# Altium schematic sheet BARBAROV Power Distrubution Board.SchDoc("BARBAROV Power Distrubution Board")
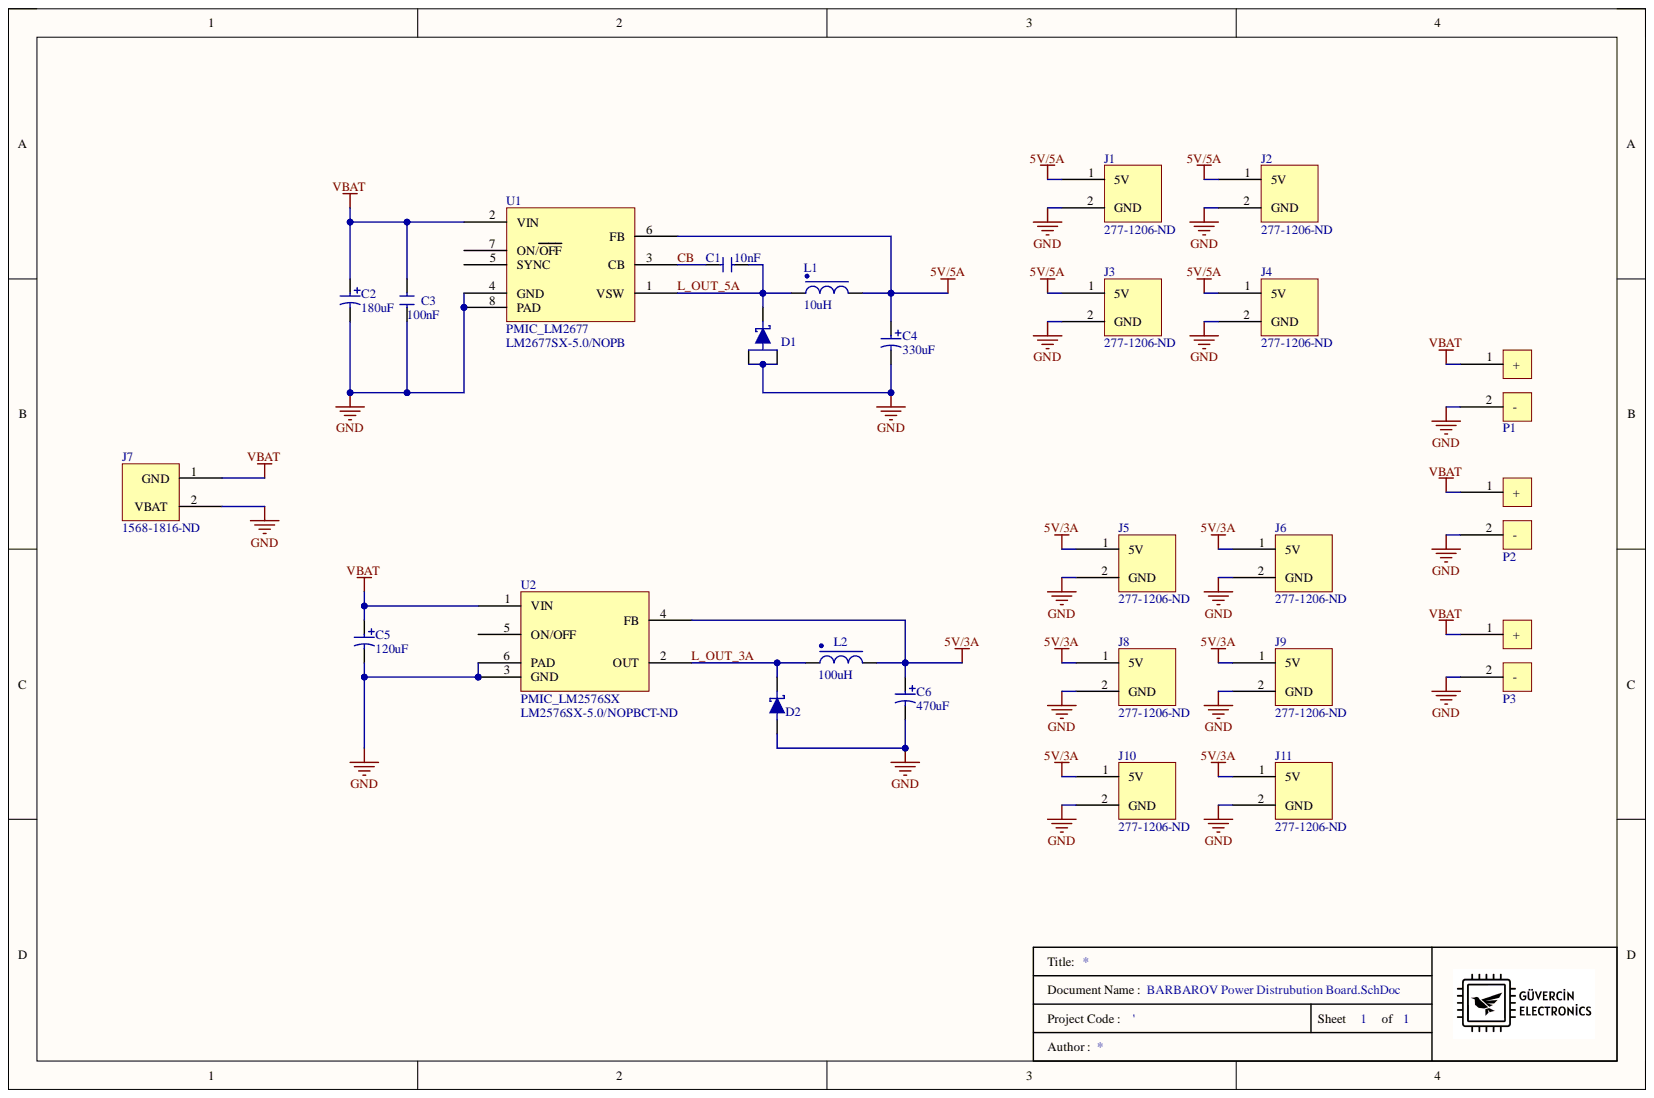
[diagram p0: BARBAROV Power Distrubution Board.SchDoc]

## Parts
C1  Capacitance: 10nF  [0603_CAP]  (hidden comment: CAP_10nF_%20_0603_50V_X7R_CER)
C2  Capacitance: 180uF  [RADIAL-2_8.30MMX8.30MM_PANASONIC]  (hidden comment: CAP_180uF_20%_25V_SMD_ALUM POLY_8.30mmx8.30mm)
C3  Capacitance: 100nF  [0805_CAP]  (hidden comment: CAP_100nF_50V_0805_C0G_CER)
C4  Capacitance: 330uF  [RADIAL-2_8.30MMX8.30MM_PANASONIC]  (hidden comment: CAP_330uF_20%_16V_SMD_ALUM POLY_8.30mm x 8.30mm)
C5  Capacitance: 120uF  [CAP_6SVPC330M]  (hidden comment: CAP_120uF_20%_20V_ALUM POLY_SMD)
C6  470uF  [CAP_EEVTG1C471Q]
D1  DIODE_SCHOTTKY_200V_10A_DPAK  [3PIN_DPAK_DIODE]  (value not drawn)
D2  DIODE_SCHOTTKY_60V_5A_SMC  [DIOM6959X25M]  (value not drawn)
J1  Supplier Part Number 1: 277-1206-ND  [CONN TERM BLOCK HDR 2POS 90DEG 3.81MM]  (hidden comment: CONN_TERM BLOCK_HDR_2POS_VERT_3.81MM)
J2  Supplier Part Number 1: 277-1206-ND  [CONN TERM BLOCK HDR 2POS 90DEG 3.81MM]  (hidden comment: CONN_TERM BLOCK_HDR_2POS_VERT_3.81MM)
J3  Supplier Part Number 1: 277-1206-ND  [CONN TERM BLOCK HDR 2POS 90DEG 3.81MM]  (hidden comment: CONN_TERM BLOCK_HDR_2POS_VERT_3.81MM)
J4  Supplier Part Number 1: 277-1206-ND  [CONN TERM BLOCK HDR 2POS 90DEG 3.81MM]  (hidden comment: CONN_TERM BLOCK_HDR_2POS_VERT_3.81MM)
J5  Supplier Part Number 1: 277-1206-ND  [CONN TERM BLOCK HDR 2POS 90DEG 3.81MM]  (hidden comment: CONN_TERM BLOCK_HDR_2POS_VERT_3.81MM)
J6  Supplier Part Number 1: 277-1206-ND  [CONN TERM BLOCK HDR 2POS 90DEG 3.81MM]  (hidden comment: CONN_TERM BLOCK_HDR_2POS_VERT_3.81MM)
J7  Supplier Part Number 1: 1568-1816-ND  [XT60_CONN]  (hidden comment: CONN_XT60)
J8  Supplier Part Number 1: 277-1206-ND  [CONN TERM BLOCK HDR 2POS 90DEG 3.81MM]  (hidden comment: CONN_TERM BLOCK_HDR_2POS_VERT_3.81MM)
J9  Supplier Part Number 1: 277-1206-ND  [CONN TERM BLOCK HDR 2POS 90DEG 3.81MM]  (hidden comment: CONN_TERM BLOCK_HDR_2POS_VERT_3.81MM)
J10  Supplier Part Number 1: 277-1206-ND  [CONN TERM BLOCK HDR 2POS 90DEG 3.81MM]  (hidden comment: CONN_TERM BLOCK_HDR_2POS_VERT_3.81MM)
J11  Supplier Part Number 1: 277-1206-ND  [CONN TERM BLOCK HDR 2POS 90DEG 3.81MM]  (hidden comment: CONN_TERM BLOCK_HDR_2POS_VERT_3.81MM)
L1  Inductance: 10uH  [IND13.70MM13.70MMX7MM]  (hidden comment: IND_10uH_10A_16.8MOHM_SMD)
L2  Inductance: 100uH  [SMD_IND_22.48MMX22.00MM]  (hidden comment: IND_100uH_9.1A_39.4MOHM_SM)
P1  ESC_PAD  [ESC_SOLDER_PAD]  (value not drawn)  (no BOM)
P2  ESC_PAD  [ESC_SOLDER_PAD]  (value not drawn)  (no BOM)
P3  ESC_PAD  [ESC_SOLDER_PAD]  (value not drawn)  (no BOM)
U1  PMIC_LM2677  Manufacturer Part Number: LM2677SX-5.0/NOPB  [DPAK127P1435X464-8M]
U2  PMIC_LM2576SX  Supplier Part Number 1: LM2576SX-5.0/NOPBCT-ND  [TO-263-6/DDPAK]

## Nets
5V/3A: C6.1 J5.1 J6.1 J8.1 J9.1 J10.1 J11.1 L2.2 U2.4(FB)
5V/5A: C4.1 J1.1 J2.1 J3.1 J4.1 L1.2 U1.6(FB)
GND: C2.2 C3.2 C4.2 C5.2 C6.2 D1.1 D1.3 D2.2 J1.2 J2.2 J3.2 J4.2 J5.2 J6.2 J7.2 J8.2 J9.2 J10.2 J11.2 P1.2 P2.2 P3.2 U1.4(GND) U1.8(PAD) U2.3(GND) U2.6(PAD)
VBAT: C2.1 C3.1 C5.1 J7.1 P1.1 P2.1 P3.1 U1.2(VIN) U2.1(VIN)
CB: C1.1 U1.3(CB)
L_OUT_3A: D2.1 L2.1 U2.2(OUT)
L_OUT_5A: C1.2 D1.2 L1.1 U1.1(VSW)

Not connected: U1 5(SYNC) 7(ON/OFF); U2 5(ON/OFF)

## Library data of the parts
C1 = CAP_10nF_%20_0603_50V_X7R_CER: Cap Ceramic 0.01uF 50V X7R 20% SMD 0603 125°C Paper T/R; Manufacturer: KEMET; Manufacturer Part Number: C0603C103M5RAC7411; Case Code (Metric): 1608; Case Code (Imperial): 0603; Case/Package: 0603; Category: Ceramic Capacitors; Component Kind: Standard; ComponentLink1Description: http://www.mouser.com/ds/2/212/KEM_C1002_X7R_SMD-1102033.pdf?src-supplier=Mouser; ComponentLink1URL: http://www.mouser.com/ds/2/212/KEM_C1002_X7R_SMD-1102033.pdf?src-supplier=Mouser; ComponentLink2Description: http://capacitoredge.kemet.com/capedge2/DataSheet?pn=C0603C103M5RAC7411&src-supplier=Avnet; ComponentLink2URL: http://capacitoredge.kemet.com/capedge2/DataSheet?pn=C0603C103M5RAC7411&src-supplier=Avnet; Construction: Flat; Contact Plating: Tin,Matte; Depth: 0.8mm; Dielectric: X7R; Dielectric Material: Ceramic; Height: 0.8mm; Lead Pitch: 0.7mm; Length: 1.6mm; Library Name: Main Component Library.IntLib; Max Operating Temperature: 125°C; Min Operating Temperature: -55°C; Mount: Surface Mount; Package Quantity: 50000; Packaging: Tape and Reel; Pricing 1: 1=0,10000, 10=0,03000, 50=0,01640, 100=0,01350, 500=0,00962, 1000=0,00756, 2500=0,00688, 5000=0,00632 (USD); Radiation Hardening: No; RoHS: Yes; RoHS Compliant: Yes; Series: C0603C; Stock 1: 173355; Supplier 1: Digi-Key; Supplier Part Number 1: 399-C0603C103M5RAC7411CT-ND; Termination: SMD/SMT; Tolerance: 20%; Voltage Rating: 50V; Voltage Rating (DC): 50V
C2 = CAP_180uF_20%_25V_SMD_ALUM POLY_8.30mmx8.30mm: Cap Aluminum Polymer 180uF 25V 20% Cylindrical 16m Ohm 1470mA 2000 hr 125°C Emboss T/R; Manufacturer: Panasonic; Manufacturer Part Number: 25SVT180M; Category: Polymer Capacitors; Component Kind: Standard; Library Name: Main Component Library.IntLib; Pricing 1: 1=3,01000, 10=2,43800, 50=2,12720, 100=1,86140 (USD); RoHS: Yes; Stock 1: 129; Supplier 1: Digi-Key; Supplier Part Number 1: 10-25SVT180MCT-ND
C3 = CAP_100nF_50V_0805_C0G_CER: Cap Ceramic 0.1uF 50V X7R 20% SMD 0805 125C Paper T/R; Manufacturer: KEMET; Manufacturer Part Number: C0805C104M5RACTU; Case/Package: 0805; Category: Ceramic Capacitors; Component Kind: Standard; ComponentLink1Description: http://uk.rs-online.com/webdocs/13da/0900766b813daa06.pdf?src-supplier=RSComponents; ComponentLink1URL: http://uk.rs-online.com/webdocs/13da/0900766b813daa06.pdf?src-supplier=RSComponents; ComponentLink2Description: http://uk.rs-online.com/webdocs/1515/0900766b81515223.pdf?src-supplier=RSComponents; ComponentLink2URL: http://uk.rs-online.com/webdocs/1515/0900766b81515223.pdf?src-supplier=RSComponents; ComponentLink3Description: http://www.farnell.com/datasheets/2739857.pdf?src-supplier=Farnell; ComponentLink3URL: http://www.farnell.com/datasheets/2739857.pdf?src-supplier=Farnell; ComponentLink4Description: http://components.arrow.com/part/dataview/0323_00324812?src-supplier=Arrow+Europe; ComponentLink4URL: http://components.arrow.com/part/dataview/0323_00324812?src-supplier=Arrow+Europe; ComponentLink5Description: http://uk.rs-online.com/webdocs/1528/0900766b81528e2f.pdf?src-supplier=RSComponents; ComponentLink5URL: http://uk.rs-online.com/webdocs/1528/0900766b81528e2f.pdf?src-supplier=RSComponents; ComponentLink6Description: http://www.farnell.com/datasheets/2739857.pdf?src-supplier=Newark; ComponentLink6URL: http://www.farnell.com/datasheets/2739857.pdf?src-supplier=Newark; ComponentLink7Description: https://api.kemet.com/component-edge/download/datasheet/C0805C104M5RACTU.pdf?src-supplier=Digi-Key; ComponentLink7URL: https://api.kemet.com/component-edge/download/datasheet/C0805C104M5RACTU.pdf?src-supplier=Digi-Key; ComponentLink8Description: http://www.farnell.com/datasheets/2739857.pdf?src-supplier=Element14; ComponentLink8URL: http://www.farnell.com/datasheets/2739857.pdf?src-supplier=Element14; ComponentLink9Description: http://www.alliedelec.com/m/d/9bfe7773041ffe9d406e68bc7b377fdd.pdf?src-supplier=Allied+Electronics; ComponentLink9URL: http://www.alliedelec.com/m/d/9bfe7773041ffe9d406e68bc7b377fdd.pdf?src-supplier=Allied+Electronics; ComponentLink10Description: http://www.mouser.com/ds/2/212/KEM_C1002_X7R_SMD-1102033.pdf?src-supplier=Mouser; ComponentLink10URL: http://www.mouser.com/ds/2/212/KEM_C1002_X7R_SMD-1102033.pdf?src-supplier=Mouser; ComponentLink11Description: http://capacitoredge.kemet.com/capedge2/DataSheet?pn=C0805C104M5RACTU&src-supplier=Avnet+Europe; ComponentLink11URL: http://capacitoredge.kemet.com/capedge2/DataSheet?pn=C0805C104M5RACTU&src-supplier=Avnet+Europe; Depth: 1.25mm; Dielectric: X7R; Dielectric Material: Ceramic; Height: 0.9mm; Length: 2mm; Library Name: Main Component Library.IntLib; Max Operating Temperature: 125°C; Min Operating Temperature: -55°C; Mount: Surface Mount; Packaging: Tape and Reel; Pricing 1: 1=0,10000, 10=0,06400, 50=0,03500, 100=0,02860, 500=0,02040, 1000=0,01603 (USD); Radiation Hardening: No; RoHS: Yes; Stock 1: 4863; Supplier 1: Digi-Key; Supplier Part Number 1: 399-C0805C104M5RAC7800CT-ND; Tolerance: 20%; Voltage Rating (DC): 50V
C4 = CAP_330uF_20%_16V_SMD_ALUM POLY_8.30mm x 8.30mm: Cap Alum Poly 330UF 20% 16V SMD; Manufacturer: Wurth Electronics; Manufacturer Part Number: 875075355004; ESR (Equivalent Series Resistance): 10mR; Life (Hours): 2000Hour; Polarity: Polar; Ripple Current: 4.7A; Case/Package: Radial; Category: Polymer Capacitors; Component Kind: Standard; ComponentLink1Description: http://www.farnell.com/datasheets/1880458.pdf?src-supplier=Farnell; ComponentLink1URL: http://www.farnell.com/datasheets/1880458.pdf?src-supplier=Farnell; ComponentLink2Description: http://katalog.we-online.de/pbs/datasheet/875075355004.pdf?src-supplier=Digi-Key; ComponentLink2URL: http://katalog.we-online.de/pbs/datasheet/875075355004.pdf?src-supplier=Digi-Key; ComponentLink3Description: http://www.farnell.com/datasheets/1880458.pdf?src-supplier=Element14; ComponentLink3URL: http://www.farnell.com/datasheets/1880458.pdf?src-supplier=Element14; Height - Seated (Max): 0.461inch; Library Name: Main Component Library.IntLib; Max Operating Temperature: 105°C; Min Operating Temperature: -55°C; Mount: Surface Mount; Package Quantity: 400; Packaging: Tape and Reel; Pricing 1: 1=1,18000, 50=1,00600, 100=0,87700 (USD); REACH SVHC: Unknown; RoHS: Yes; RoHS Compliant: Yes; Stock 1: 2224; Supplier 1: Digi-Key; Supplier Part Number 1: 732-6491-1-ND; Tolerance: 20%; Voltage Rating: 16V; Voltage Rating (DC): 16V
C5 = CAP_120uF_20%_20V_ALUM POLY_SMD: Cap Aluminum Polymer 120uF 20VDC 20%( 6.3 X 5.9mm) SMD 0.025 Ohm 3200mA 5000h 105C T/R; Manufacturer: Panasonic; Manufacturer Part Number: 20SVPF120M; Dissipation Factor: 12%; ESR (Equivalent Series Resistance): 25mR; Leakage Current: 480uA; Life (Hours): 5000Hour; Polarity: Polar; Ripple Current: 3.2A; Voltage: 20V; Case/Package: Radial; Category: Aluminum Electrolytic Capacitors; Component Kind: Standard; ComponentLink1Description: https://datasheet.ciiva.com/24432/svpf-series-datasheet-24432811.pdf?src-supplier=Onlinecomponents.com; ComponentLink1URL: https://datasheet.ciiva.com/24432/svpf-series-datasheet-24432811.pdf?src-supplier=Onlinecomponents.com; ComponentLink2Description: https://datasheet.ciiva.com/25746/1734360-25746680.pdf?src-supplier=Farnell; ComponentLink2URL: https://datasheet.ciiva.com/25746/1734360-25746680.pdf?src-supplier=Farnell; ComponentLink3Description: https://datasheet.ciiva.com/22746/1734360-22746206.pdf?src-supplier=Element14; ComponentLink3URL: https://datasheet.ciiva.com/22746/1734360-22746206.pdf?src-supplier=Element14; ComponentLink4Description: https://datasheet.ciiva.com/46650/70324301-46650876.pdf?src-supplier=Allied+Electronics; ComponentLink4URL: https://datasheet.ciiva.com/46650/70324301-46650876.pdf?src-supplier=Allied+Electronics; Diameter: 6.3mm; Height: 5.9mm; Height - Seated (Max): 0.236inch; Lead Free: Lead Free; Length: 5.9mm; Library Name: Main Schematic Library.SchLib; Material: Polymer; Max Operating Temperature: 105°C; Min Operating Temperature: -55°C; Mount: Surface Mount; Number of Pins: 6; Package Quantity: 1000; Packaging: Tape and Reel; Pricing 1: 1=1,41000, 10=1,11000, 50=0,96240, 100=0,83280, 500=0,62922 (USD); Radiation Hardening: No; REACH SVHC: No SVHC; Ripple Current (AC): 3.2A; RoHS: Yes; RoHS Compliant: Yes; Stock 1: 5; Supplier 1: Digi-Key; Supplier Part Number 1: P16498CT-ND; Termination: SMD/SMT; Tolerance: 20%; Voltage Rating: 20V; Voltage Rating (DC): 20V
C6 = CAP_470uF_20%_16V_SMD_ALUM: 贴片铝电解电容 470uF ±20% 16V 0.12Ω 2000hrs@125°C AEC_D12.5x13.5mm_SM; Manufacturer: Panasonic; Manufacturer Part Number: EEV-TG1C471Q; Dissipation Factor: 0.23%; ESR (Equivalent Series Resistance): 120mR; Life (Hours): 2000Hour; Polarity: Polar; Ripple Current: 800mA; Capacitance: 470uF; Case/Package: Radial; Category: Aluminum Electrolytic Capacitors; Component Kind: Standard; ComponentLink1Description: https://datasheet.ciiva.com/8081/aba0000ce101-8081367.pdf?src-supplier=Element14; ComponentLink1URL: https://datasheet.ciiva.com/8081/aba0000ce101-8081367.pdf?src-supplier=Element14; ComponentLink2Description: https://datasheet.ciiva.com/43470/1678958-43470874.pdf?src-supplier=Farnell; ComponentLink2URL: https://datasheet.ciiva.com/43470/1678958-43470874.pdf?src-supplier=Farnell; ComponentLink3Description: https://datasheet.ciiva.com/46379/1678958-46379244.pdf?src-supplier=Element14; ComponentLink3URL: https://datasheet.ciiva.com/46379/1678958-46379244.pdf?src-supplier=Element14; ComponentLink4Description: https://datasheet.ciiva.com/31417/1678958-31417838.pdf?src-supplier=Farnell; ComponentLink4URL: https://datasheet.ciiva.com/31417/1678958-31417838.pdf?src-supplier=Farnell; ComponentLink5Description: https://datasheet.ciiva.com/46372/1678958-46372616.pdf?src-supplier=Element14; ComponentLink5URL: https://datasheet.ciiva.com/46372/1678958-46372616.pdf?src-supplier=Element14; ComponentLink6Description: https://datasheet.ciiva.com/24025/aba0000ce101-30808-24025129.pdf?src-supplier=Mouser; ComponentLink6URL: https://datasheet.ciiva.com/24025/aba0000ce101-30808-24025129.pdf?src-supplier=Mouser; ComponentLink7Description: https://datasheet.ciiva.com/14598/70068299-14598696.pdf?src-supplier=Allied+Electronics; ComponentLink7URL: https://datasheet.ciiva.com/14598/70068299-14598696.pdf?src-supplier=Allied+Electronics; ComponentLink8Description: https://datasheet.ciiva.com/11679/aba0000ce101-11679349.pdf?src-supplier=Avnet; ComponentLink8URL: https://datasheet.ciiva.com/11679/aba0000ce101-11679349.pdf?src-supplier=Avnet; ComponentLink9Description: https://datasheet.ciiva.com/30085/aba0000ce101-30085800.pdf?src-supplier=Onlinecomponents.com; ComponentLink9URL: https://datasheet.ciiva.com/30085/aba0000ce101-30085800.pdf?src-supplier=Onlinecomponents.com; Construction: Cylindrical; Diameter: 12.5mm; Height: 13.5mm; Height - Seated (Max): 0.531inch; Lead Free: Lead Free; Length: 13.5mm; Library Name: Main Component Library.IntLib; Max Operating Temperature: 125°C; Min Operating Temperature: -40°C; Mount: Surface Mount,PCB; Package Quantity: 200; Packaging: Tape and Reel; Pricing 1: 1=1,92000, 10=1,51400, 50=1,31240, 100=1,13570 (USD); Radiation Hardening: No; REACH SVHC: No SVHC; Ripple Current (AC): 800mA; RoHS: Yes; RoHS Compliant: Yes; Series: TG; Stock 1: 6175; Supplier 1: Digi-Key; Supplier Part Number 1: PCE3629CT-ND; Termination: SMD/SMT; Tolerance: 20%; Voltage Rating: 16V; Voltage Rating (DC): 16V; Width: 900um
D1 = DIODE_SCHOTTKY_200V_10A_DPAK: Diode Schottky 200V 10A Dpak; Manufacturer: Sangdest Microelectronics; Manufacturer Part Number: SBRD10200; Category: Uncategorized; Component Kind: Standard; Library Name: Main Component Library.IntLib; Pricing 1: 1=0,59000, 10=0,50300, 100=0,37580, 500=0,29524, 1000=0,22814 (USD); RoHS: No; Stock 1: 138862; Supplier 1: Digi-Key; Supplier Part Number 1: 1655-SBRD10200CT-ND
D2 = DIODE_SCHOTTKY_60V_5A_SMC: VR=60V IF=5A 300pF; Manufacturer: Diodes; Manufacturer Part Number: B560C-13-F; Average Rectified Current: 5A; Element Configuration: Single; Forward Voltage: 700mV; Max Forward Surge Current (Ifsm): 100A; Max Repetitive Reverse Voltage (Vrrm): 60V; Max Reverse Current: 500uA; Polarity: Standard; Capacitance: 300pF; Case/Package: SMC; Category: Rectifier Diodes; Component Kind: Standard; ComponentLink1Description: https://datasheet.ciiva.com/27052/1538961-27052355.pdf?src-supplier=Jameco; ComponentLink1URL: https://datasheet.ciiva.com/27052/1538961-27052355.pdf?src-supplier=Jameco; ComponentLink2Description: https://datasheet.ciiva.com/1177/ds13012-1177532.pdf?src-supplier=Element14; ComponentLink2URL: https://datasheet.ciiva.com/1177/ds13012-1177532.pdf?src-supplier=Element14; ComponentLink3Description: https://datasheet.ciiva.com/16389/ds13012-16389735.pdf?src-supplier=Future+Electronics; ComponentLink3URL: https://datasheet.ciiva.com/16389/ds13012-16389735.pdf?src-supplier=Future+Electronics; ComponentLink4Description: https://datasheet.ciiva.com/15144/getdatasheetpartid-89786-15144652.pdf?src-supplier=Verical; ComponentLink4URL: https://datasheet.ciiva.com/15144/getdatasheetpartid-89786-15144652.pdf?src-supplier=Verical; ComponentLink5Description: https://datasheet.ciiva.com/914/ds13012-914986.pdf?src-supplier=Digi-Key; ComponentLink5URL: https://datasheet.ciiva.com/914/ds13012-914986.pdf?src-supplier=Digi-Key; ComponentLink6Description: https://datasheet.ciiva.com/914/ds13012-914987.pdf?src-supplier=Digi-Key; ComponentLink6URL: https://datasheet.ciiva.com/914/ds13012-914987.pdf?src-supplier=Digi-Key; ComponentLink7Description: https://datasheet.ciiva.com/914/ds13012-914985.pdf?src-supplier=Digi-Key; ComponentLink7URL: https://datasheet.ciiva.com/914/ds13012-914985.pdf?src-supplier=Digi-Key; Contact Plating: Tin,Matte; Current Rating: 5A; Forward Current: 5A; Height: 2.5mm; Lead Free: Lead Free; Length: 7.11mm; Library Name: Main Component Library.IntLib; Max Operating Temperature: 125°C; Max Reverse Voltage (DC): 60V; Min Operating Temperature: -55°C; Mount: Surface Mount; Number of Pins: 2; Output Current: 5A; Package Quantity: 3000; Packaging: Tape and Reel; Peak Non-Repetitive Surge Current: 100A; Pricing 1: 1=0,56000, 10=0,47600, 100=0,35570, 500=0,27952, 1000=0,21598 (USD); Radiation Hardening: No; REACH SVHC: No SVHC; RoHS: Yes; RoHS Compliant: Yes; Series: -; Stock 1: 2959; Supplier 1: Digi-Key; Supplier Part Number 1: B560C-FDICT-ND; Termination: SMD/SMT; Voltage Rating (DC): 60V; Weight: 0.007408oz; Width: 6.22mm
J1-J6 J8-J11 = CONN_TERM BLOCK_HDR_2POS_VERT_3.81MM: 2 Position Terminal Block Header, Male Pins, Shrouded (4 Side) 0.150" (3.81mm) 90°, Right Angle - Through Hole; Manufacturer: Phoenix Contact; Manufacturer Part Number: 1803277; Approvals: UL,CSA; Connector Type: Plug,PCB,Terminal Block; Current: 8A; Number of Contacts: 2; Number of Levels: 1; Voltage: 300V; Voltage Rating: 160V; Voltage Rating (DC): 640mV; Category: Terminal Blocks; Color: Green; Component Kind: Standard; ComponentLink1Description: https://datasheet.ciiva.com/16096/1803277-16096845.pdf?src-supplier=Onlinecomponents.com; ComponentLink1URL: https://datasheet.ciiva.com/16096/1803277-16096845.pdf?src-supplier=Onlinecomponents.com; ComponentLink2Description: https://datasheet.ciiva.com/26840/getdatasheetpartid-233794-26840495.pdf?src-supplier=Verical; ComponentLink2URL: https://datasheet.ciiva.com/26840/getdatasheetpartid-233794-26840495.pdf?src-supplier=Verical; ComponentLink3Description: https://datasheet.ciiva.com/11746/0900766b80029ea6-11746612.pdf?src-supplier=RSComponents; ComponentLink3URL: https://datasheet.ciiva.com/11746/0900766b80029ea6-11746612.pdf?src-supplier=RSComponents; ComponentLink4Description: https://datasheet.ciiva.com/795/1803277-795413.pdf?src-supplier=Digi-Key; ComponentLink4URL: https://datasheet.ciiva.com/795/1803277-795413.pdf?src-supplier=Digi-Key; ComponentLink5Description: https://datasheet.ciiva.com/23596/1581611-23596276.pdf?src-supplier=Newark; ComponentLink5URL: https://datasheet.ciiva.com/23596/1581611-23596276.pdf?src-supplier=Newark; ComponentLink6Description: https://datasheet.ciiva.com/29212/740533-da-01-de-leiterplattenstecker-mc-1-5-2-g-3-81-29212066.pdf?src-supplier=Conrad; ComponentLink6URL: https://datasheet.ciiva.com/29212/740533-da-01-de-leiterplattenstecker-mc-1-5-2-g-3-81-29212066.pdf?src-supplier=Conrad; Contact Gender: Male; Contact Material: Tin; Contact Plating: Tin; Current Rating: 8A; Depth: 9.2mm; Flammability Rating: UL94 V-0; Gender: Male; Height: 9.2mm; Housing Color: Green; Housing Material: Polybutylene; Lead Free: Lead Free; Length: 9.01mm; Library Name: Main Component Library.IntLib; Max Current Rating: 8A; Mount: Through Hole; Number of Poles: 2; Number of Positions: 2; Number of Positions Per Level: 2; Number of Rows: 1; Orientation: Right Angle; Package Quantity: 50; Packaging: Bulk; Pitch: 3.81mm; Pricing 1: 1=0,91000, 10=0,87400, 50=0,83600, 100=0,81320, 250=0,76000, 500=0,69920, 1000=0,64600, 2500=0,61560, 5000=0,58520 (USD); REACH SVHC: Unknown; RoHS: Yes; RoHS Compliant: Yes; Series: MC; Stock 1: 18415; Supplier 1: Digi-Key; Termination: Solder; Voltage Rating (AC): 160V; Weight: 4oz; Width: 10.65mm
J7 = CONN_XT60: XT60 Connectors Male/female Pair; Manufacturer: SparkFun; Manufacturer Part Number: PRT-10474; Component Kind: Standard; ComponentLink1Description: https://cdn.sparkfun.com/datasheets/Prototyping/xt60.pdf?src-supplier=Digi-Key; ComponentLink1URL: https://cdn.sparkfun.com/datasheets/Prototyping/xt60.pdf?src-supplier=Digi-Key; Library Name: Main Schematic Library.SchLib; Pricing 1: 1=1,50000 (USD); RoHS: No; Stock 1: 2196; Supplier 1: Digi-Key
L1 = IND_10uH_10A_16.8MOHM_SMD: Inductor Power Shielded Wirewound 10uH 20% 100KHz Iron 10A 0.0168Ohm DCR T/R; Manufacturer: Bourns; Manufacturer Part Number: SRP1270-100M; Core Material: Ferrite; Current: 15A; DC Resistance (DCR): 16.8mR; Saturation Current: 16A; Self Resonant Frequency: 10MHz; Case/Package: Nonstandard; Category: Fixed Inductors; Component Kind: Standard; ComponentLink1Description: https://datasheet.ciiva.com/22704/1834359-22704150.pdf?src-supplier=Element14; ComponentLink1URL: https://datasheet.ciiva.com/22704/1834359-22704150.pdf?src-supplier=Element14; ComponentLink2Description: https://datasheet.ciiva.com/11802/0900766b80f2b679-11802877.pdf?src-supplier=RSComponents; ComponentLink2URL: https://datasheet.ciiva.com/11802/0900766b80f2b679-11802877.pdf?src-supplier=RSComponents; ComponentLink3Description: https://datasheet.ciiva.com/3082/srp1270-3082275.pdf?src-supplier=Avnet; ComponentLink3URL: https://datasheet.ciiva.com/3082/srp1270-3082275.pdf?src-supplier=Avnet; ComponentLink4Description: https://datasheet.ciiva.com/6698/srp1270-6698645.pdf?src-supplier=Digi-Key; ComponentLink4URL: https://datasheet.ciiva.com/6698/srp1270-6698645.pdf?src-supplier=Digi-Key; ComponentLink5Description: https://datasheet.ciiva.com/6698/srp1270-6698646.pdf?src-supplier=Digi-Key; ComponentLink5URL: https://datasheet.ciiva.com/6698/srp1270-6698646.pdf?src-supplier=Digi-Key; ComponentLink6Description: https://datasheet.ciiva.com/15160/getdatasheetpartid-137626-15160492.pdf?src-supplier=Verical; ComponentLink6URL: https://datasheet.ciiva.com/15160/getdatasheetpartid-137626-15160492.pdf?src-supplier=Verical; ComponentLink7Description: https://datasheet.ciiva.com/17286/srp1270-17286206.pdf?src-supplier=Avnet+Europe; ComponentLink7URL: https://datasheet.ciiva.com/17286/srp1270-17286206.pdf?src-supplier=Avnet+Europe; Current Rating: 10A; DC Current: 10A; Depth: 13.7mm; Height: 7mm; Height - Seated (Max): 0.276inch; Length: 13.7mm; Library Name: Main Component Library.IntLib; Max DC Current: 10A; Max Operating Temperature: 125°C; Military Standard: Not; Min Operating Temperature: -55°C; Mount: Surface Mount; Package Quantity: 400; Packaging: Tape and Reel; Pricing 1: 1=1,83000, 10=1,69100, 25=1,54960, 50=1,32420, 100=1,18340 (USD); Radiation Hardening: No; REACH SVHC: No SVHC; Resistor Type: Wirewound; RMS Current (Irms): 10A; RoHS: Yes; RoHS Compliant: Yes; Series: SRP1270; Shielding: Shielded; Stock 1: 10712; Supplier 1: Digi-Key; Supplier Part Number 1: SRP1270-100MCT-ND; Termination: SMD/SMT; Test Frequency: 100kHz; Tolerance: 20%; Width: 0.539inch; Inductance Tolerance: 20%
L2 = IND_100uH_9.1A_39.4MOHM_SM: Inductor Power Shielded Wirewound 100uH 20% 100KHz Powdered Iron 9.1A 0.0394Ohm DCR 8787 T/R; Manufacturer: Vishay Dale; Manufacturer Part Number: IHLP8787MZER101M51; DC Resistance (DCR): 39.4mR; Case/Package: 8787; Category: Inductors; Component Kind: Standard; ComponentLink1Description: http://www.mouser.com/ds/2/427/ihlp8787mz51-537150.pdf?src-supplier=Mouser; ComponentLink1URL: http://www.mouser.com/ds/2/427/ihlp8787mz51-537150.pdf?src-supplier=Mouser; Height: 13mm; Length: 22mm; Library Name: Main Component Library.IntLib; Max DC Current: 9.1A; Max Operating Temperature: 155°C; Min Operating Temperature: -55°C; Package Quantity: 100; Packaging: Tape and Reel; Pricing 1: 1=10,02000, 10=8,91000, 25=8,24160, 50=7,12800 (USD); RoHS: Yes; RoHS Compliant: Yes; Shielding: Shielded; Stock 1: 27; Supplier 1: Digi-Key; Supplier Part Number 1: 541-IHLP8787MZER101M51CT-ND; Termination: SMD/SMT; Tolerance: 20%; Width: 22.48mm
U1 = PMIC_LM2677: SIMPLE SWITCHE High Efficiency 5A Step-Down Voltage egulator with Sync 7-DDPAK/TO-263 -40 to 125; Manufacturer: TI National Semiconductor; Efficiency: 84%; Max Input Voltage: 40V; Min Output Voltage: 5V; Min Input Voltage: 8V; Number of Outputs: 1; Output Configuration: Positive; Output Type: Fixed; Quiescent Current: 4.2mA; Switching Frequency: 260kHz; Synchronous Rectifier: No; Case/Package: TO-263; Category: Voltage Regulators - Switching; Component Kind: Standard; ComponentLink1Description: https://datasheet.ciiva.com/15112/getdatasheetpartid-55533-15112619.pdf?src-supplier=Verical; ComponentLink1URL: https://datasheet.ciiva.com/15112/getdatasheetpartid-55533-15112619.pdf?src-supplier=Verical; ComponentLink2Description: https://datasheet.ciiva.com/26100/lm2677-26100074.pdf?src-supplier=Avnet+Europe; ComponentLink2URL: https://datasheet.ciiva.com/26100/lm2677-26100074.pdf?src-supplier=Avnet+Europe; ComponentLink3Description: https://datasheet.ciiva.com/14304/lm2677-14304284.pdf?src-supplier=Avnet; ComponentLink3URL: https://datasheet.ciiva.com/14304/lm2677-14304284.pdf?src-supplier=Avnet; Contact Plating: Tin,Matte; Lead Free: Contains Lead; Library Name: Main Component Library.IntLib; Max Operating Temperature: 125°C; Max Output Current: 5A; Min Operating Temperature: -40°C; Mount: Surface Mount; Operating Supply Voltage: 40V; Output Current: 5A; Output Voltage: 5V; Package Quantity: 500; Packaging: Tape and Reel; Pricing 1: 1=6,95000, 10=6,24400, 25=5,90280, 100=5,11580, 250=4,85348 (USD); Radiation Hardening: No; RoHS: Yes; RoHS Compliant: Yes; Stock 1: 763; Supplier 1: Digi-Key; Supplier Part Number 1: 296-48568-1-ND
U2 = PMIC_LM2576SX: IC REG BUCK 5V 3A TO263-5; Manufacturer: TI National Semiconductor; Manufacturer Part Number: LM2576SX-5.0/NOPB; Efficiency: 77%; Max Input Voltage: 40V; Min Input Voltage: 4V; Number of Outputs: 1; Output Type: Fixed; Quiescent Current: 5mA; Switching Frequency: 52kHz; Synchronous Rectifier: No; Case/Package: TO-263-6; Category: Voltage Regulators - Switching; Component Kind: Standard; ComponentLink1Description: https://datasheet.ciiva.com/41457/001013741-da-01-en-ic-reg-bu-lm2576sx-5-0-nopb-to-263-5-tid-41457614.pdf?src-supplier=Conrad; ComponentLink1URL: https://datasheet.ciiva.com/41457/001013741-da-01-en-ic-reg-bu-lm2576sx-5-0-nopb-to-263-5-tid-41457614.pdf?src-supplier=Conrad; Contact Plating: Tin; Lead Free: Contains Lead; Library Name: Main Component Library.IntLib; Max Operating Temperature: 125°C; Max Output Current: 3A; Min Operating Temperature: -40°C; Mount: Surface Mount; Nominal Output Voltage: 5V; Number of Pins: 5; Operating Supply Voltage: 40V; Output Current: 3A; Output Voltage: 5V; Package Quantity: 500; Packaging: Tape and Reel; Pricing 1: 1=4,06000, 10=3,64300, 25=3,44400, 100=2,98470, 250=2,83168 (USD); Radiation Hardening: No; REACH SVHC: No SVHC; RoHS: Yes; RoHS Compliant: Yes; Series: SIMPLE SWITCHER®; Stock 1: 2560; Supplier 1: Digi-Key
P1-P3 = ESC_PAD: Library Name: Main Component Library.IntLib
